# Altium schematic sheet SOC.SchDoc(SOC)
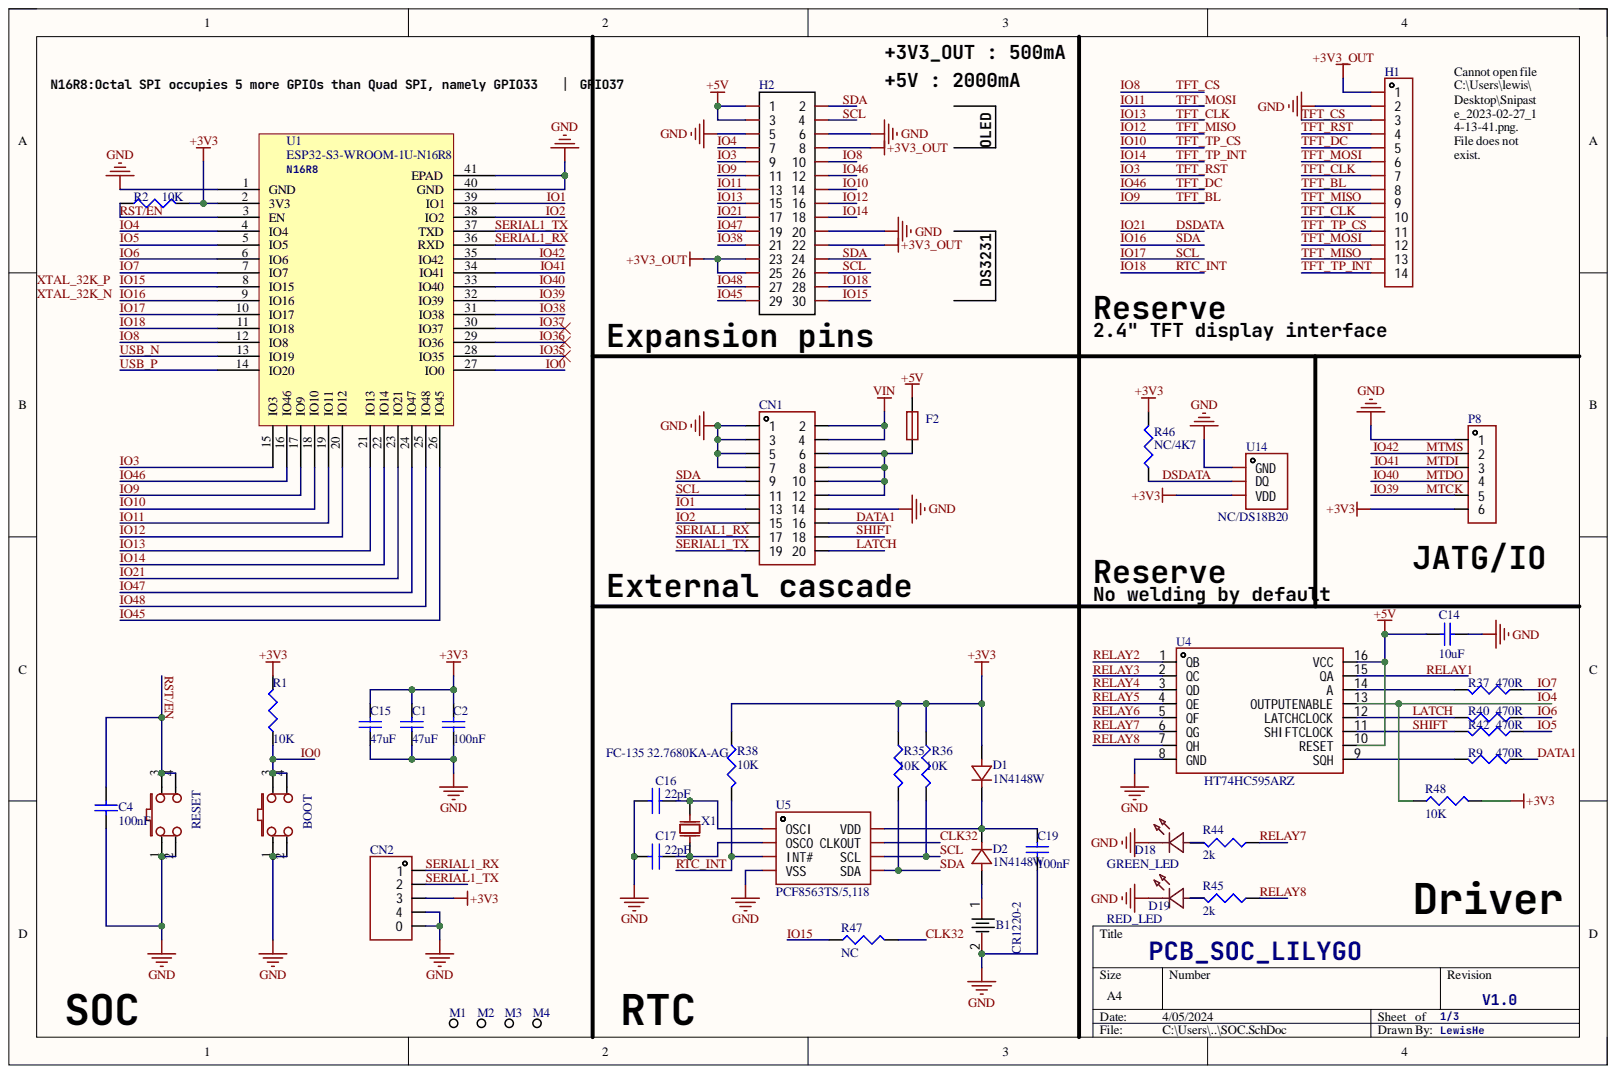
[diagram p0: SOC.SchDoc]

## Parts
B1  CR1220-2  [BAT-SMD_CR1220-2]
C1  47uF  [C0805]
C2  100nF  [C0603]
C4  100nF  [C0603]
C14  10uF  [C0603]
C15  47uF  [C0805]
C16  22pF  [C0402]
C17  22pF  [C0402]
C19  100nF  [C0603]
CN1  321020SG0ABK00A01  [IDC-TH_20P-P2.54-V-R2-C10-S2.54]  (value not drawn)
CN2  BM04B-SRSS-TB(LF)(SN)  [CONN-SMD_BM04B-SRSS-TB]  (value not drawn)
D1  1N4148W  [SOD-123_L2.7-W1.6-LS3.8-RD]
D2  1N4148W  [SOD-123_L2.7-W1.6-LS3.8-RD]
D18  GREEN_LED  [LED0603_RED]
D19  RED_LED  [LED0603_RED]
F2  BSMD1206-200-12V  [F1206]  (value not drawn)
H1  PM254-1-14-Z-8.5  [HDR-TH_14P-P2.54-V-F-1]  (value not drawn)
H2  B-2200S30P-B120  [HDR-TH_30P-P2.54-V-F-R2-C15-S2.54-1]  (value not drawn)
KEY1  RESET  [KEY-SMD_4P-L6.0-W6.0-P4.50-LS10.0]
KEY2  BOOT  [KEY-SMD_4P-L6.0-W6.0-P4.50-LS10.0]
M1  M3螺丝孔  [M3螺丝孔]  (value not drawn)
M2  M3螺丝孔  [M3螺丝孔]  (value not drawn)
M3  M3螺丝孔  [M3螺丝孔]  (value not drawn)
M4  M3螺丝孔  [M3螺丝孔]  (value not drawn)
P8  PZ254V-11-06P  [HDR-TH_6P-P2.54-V-M]  (value not drawn)
R1  10K  [R0402]
R2  10K  [R0603]
R9  470R  [R0402]
R35  10K  [R0603]
R36  10K  [R0603]
R37  470R  [R0402]
R38  10K  [R0603]
R40  470R  [R0402]
R42  470R  [R0402]
R44  2k  [R0402]
R45  2k  [R0402]
R46  NC/4K7  [R0603]
R47  NC  [R0402]
R48  10K  [R0402]
U1  ESP32-S3-WROOM-1U-N16R8  [ESP32-S3-WROOM-1]
U4  HT74HC595ARZ  [SOIC-16_L9.9-W3.9-P1.27-LS6.0-BL]
U5  PCF8563TS/5,118  [TSSOP-8_L3.0-W3.0-P0.65-LS4.9-BL]
U14  NC/DS18B20  [TO-92-3_L4.9-W3.7-P1.27-L]
X1  FC-135 32.7680KA-AG  [CRYSTAL-SMD_L3.2-W1.5-2]

## Nets
+3V3: C1.1 C2.1 C15.1 CN2.3 D1.2 P8.6 R1.2 R2.2 R35.1 R36.1 R38.1 R46.2 R48.2 U1.2(3V3) U14.3
+3V3_OUT: H1.1 H2.8 H2.22 H2.23 H2.25
+5V: C14.1 F2.2 H2.1 H2.3 U4.10(RESET) U4.16(VCC)
GND: B1.2 C1.2 C2.2 C4.2 C14.2 C15.2 C16.2 C17.2 C19.2 CN1.1 CN1.3 CN1.5 CN1.7 CN1.14 CN2.0 CN2.4 D18.2 D19.2 H1.2 H2.5 H2.6 H2.20 KEY1.1 KEY1.2 KEY2.1 KEY2.2 P8.1 U1.1(GND) U1.40(GND) U1.41(EPAD) U4.8(GND) U5.4(VSS) U14.1
VIN: CN1.2 CN1.4
CLK32: R47.2 U5.7(CLKOUT)
DATA1: CN1.16 R9.1
DSDATA: H2.17 R46.1 U1.23(IO21) U14.2
IO0: KEY2.3 KEY2.4 R1.1 U1.27(IO0)
IO1: CN1.13 U1.39(IO1)
IO2: CN1.15 U1.38(IO2)
IO3: H1.4 H2.9 U1.15(IO3)
IO4: H2.7 R48.1 U1.4(IO4) U4.13(OUTPUTENABLE)
IO5: R42.1 U1.5(IO5)
IO6: R40.1 U1.6(IO6)
IO7: R37.1 U1.7(IO7)
IO8: H1.3 H2.10 U1.12(IO8)
IO9: H1.8 H2.11 U1.17(IO9)
IO10: H1.11 H2.14 U1.18(IO10)
IO11: H1.6 H1.12 H2.13 U1.19(IO11)
IO12: H1.9 H1.13 H2.16 U1.20(IO12)
IO13: H1.7 H1.10 H2.15 U1.21(IO13)
IO14: H1.14 H2.18 U1.22(IO14)
IO15: H2.30 R47.1 U1.8(IO15)
IO16: CN1.9 H2.2 H2.24 R35.2 U1.9(IO16) U5.5(SDA)
IO17: CN1.11 H2.4 H2.26 R36.2 U1.10(IO17) U5.6(SCL)
IO18: H2.28 R38.2 U1.11(IO18) U5.3(INT#)
IO35: U1.28(IO35)
IO36: U1.29(IO36)
IO37: U1.30(IO37)
IO38: H2.21 U1.31(IO38)
IO39: P8.5 U1.32(IO39)
IO40: P8.4 U1.33(IO40)
IO41: P8.3 U1.34(IO41)
IO42: P8.2 U1.35(IO42)
IO45: H2.29 U1.26(IO45)
IO46: H1.5 H2.12 U1.16(IO46)
IO47: H2.19 U1.24(IO47)
IO48: H2.27 U1.25(IO48)
LATCH: CN1.20 R40.2 U4.12(LATCHCLOCK)
RELAY1: U4.15(QA)
RELAY2: U4.1(QB)
RELAY3: U4.2(QC)
RELAY4: U4.3(QD)
RELAY5: U4.4(QE)
RELAY6: U4.5(QF)
RELAY7: R44.1 U4.6(QG)
RELAY8: R45.1 U4.7(QH)
RST/EN: C4.1 KEY1.3 KEY1.4 R2.1 U1.3(EN)
SERIAL1_RX: CN1.17 CN2.1 U1.36(RXD)
SERIAL1_TX: CN1.19 CN2.2 U1.37(TXD)
SHIFT: CN1.18 R42.2 U4.11(SHIFTCLOCK)
USB_N: U1.13(IO19)
USB_P: U1.14(IO20)
NetB1_1: B1.1 D2.2
NetC16_1: C16.1 U5.1(OSCI) X1.2
NetC17_1: C17.1 U5.2(OSCO) X1.1
NetC19_1: C19.1 D1.1 D2.1 U5.8(VDD)
NetCN1_6: CN1.6 CN1.8 CN1.10 CN1.12 F2.1
NetD18_1: D18.1 R44.2
NetD19_1: D19.1 R45.2
NetR9_2: R9.2 U4.9(SQH)
NetR37_2: R37.2 U4.14(A)
XTAL_32K_N:
XTAL_32K_P:

## Library data of the parts
B1 = CR1220-2: 连接器类型:电池底座;适用电池规格:CR1220;工作温度范围:-;; Component Kind: Standard; Library Name: 常用.SCHLIB
C1 C2 C4 C14 C15 C19 = Cap: Capacitor; Component Kind: Standard; LatestRevisionDate: 17-Jul-2002; LatestRevisionNote: Re-released for DXP Platform.; Library Name: Miscellaneous Devices.IntLib; PackageDescription: Radial Cap, Thru-Hole; 2 Leads; 0.3 in Pin Spacing; PackageReference: RAD-0.3; Published: 8-Jun-2000; Signal Integrity: Cap; Simulation: CAP; Publisher: Altium Limited
  C1 C15: Value: 47uF
  C2 C4 C19: Value: 100nF
  C14: Value: 10uF
C16 C17 = CAP NP_9: Manufacture: WALSIN; Manufacturer: VISHAY; Manufacturer #1???: FengHua(风华); Manufacturer_ID: 1,004; Part Number: 0603F104Z250CT; AML P/N: 123-12Z4-1040C; Description #1: 介质材料[COG（NPO）/COH]通用型属I类电容，容量稳定，不随温度、时间和电压变化而变化，适用高频电路。; Description #2: X7R贴片电容属EIA规定的Class 2类材料电容，容量随温度、时间、电压变化而变化。; Descriptions: CAP CERAMIC .1uF -20%/+80% 25V 0603 SMD RoHS walsin; Dielectric: X7R; DNS: 0; Medium material: COG(NP0)/COH/X7R; PCB Footprint: C0805; Rating Voltage: 50(A)Vdc; Tolerance: ±10%; Voltage Rating: 25V; Component Kind: Standard; Datasheet: \\File1-shanghai\sha\Specifications\Datasheets_IC\_Manufacturer\Walsin\Capacitor\MLCC catalogue_200510.pdf; Manufacturer#2: Vishay（威世）; Manufacturer#3: AVX; Part Type: SMD Capacitance（贴片电容）; VoltageRating: 25V
CN1 = 321020SG0ABK00A01: 连接器类型:简牛座;间距:2.54mm;每排PIN数:10;排数:2;行距:2.54mm;封装形式:直插;触头材质:黄铜;触头镀层:金;; Component Kind: Standard; Library Name: 常用.SCHLIB
CN2 = BM04B-SRSS-TB(LF)(SN): 参考系列:SR/SZ;间距:1mm;插针结构:1x4P;排数:1;每排PIN数:4;行距:-;安装方式:-;触头材质:铜合金;; Component Kind: Standard; Library Name: 常用.SCHLIB
D1 D2 = 1N4148W: SOD-123 贴片二极管; Component Kind: Standard; Library Name: 常用.SCHLIB
D18 = LED0603: 绿灯; Component Kind: Standard; Library Name: 常用.SCHLIB
D19 = LED0603: 红灯; Component Kind: Standard; Library Name: 常用.SCHLIB
F2 = BSMD1206-200-12V: 最大电压:12V;最大电流:100A;保持电流:2A;跳闸电流:3.5A;消耗功率:800mW;初始态阻值(最小值):15mΩ;跳断后阻值(最大值):80mΩ;最大动作时间:1.5s;工作温度:-40℃~+85℃;; Component Kind: Standard; Library Name: 常用.SCHLIB
H2 = B-2200S30P-B120: 排数:双排;总孔位数:30;间距:2.54mm;插孔结构:2x15P;行距:2.54mm;安装类型:直插;塑高:-;触头材质:黄铜;触头镀层:金;; Component Kind: Standard; Library Name: 常用.SCHLIB
KEY1 KEY2 = BUTTON_1: 6x6x0.7 按键; Component Kind: Standard; Library Name: 常用.SCHLIB
P8 = PZ254V-11-06P: 排数:单排;总PIN位数:6;间距:2.54mm;插针结构:1x6P;行距:-;安装类型:直插;圆针/方针:方针;额定电流:-;额定电压:-;配合针长度:6mm;塑高:2.5mm;端接针长度:3mm;触头材质:黄铜;触头镀层:-;工作温度范围:-40℃~+105℃;颜色:黑色;; Component Kind: Standard; Library Name: 常用.SCHLIB
R1 R2 R9 R35-R38 R40 R42 R44-R48 = Res3: Resistor; Component Kind: Standard; LatestRevisionDate: 29-May-2009; LatestRevisionNote: IPC-7351 Footprint Added.; Library Name: Miscellaneous Devices.IntLib; PackageDescription: Chip Resistor; PackageReference: J1-0603; Published: 8-Jun-2000; Signal Integrity: Res; Simulation: RESISTOR; Publisher: Altium Limited
  R1 R2 R35 R36 R38 R48: Value: 10K
  R9 R37 R40 R42: Value: 470R
  R44 R45: Value: 2k
  R46: Value: NC/4K7
  R47: Value: NC
U1 = ESP32-S3-WROOM-1: N16R8; Component Kind: Standard; Library Name: MySchlib.SchLib
U4 = HT74HC595ARZ: 8位串行输入，串行或并行输出移位寄存器与输出锁存器; Component Kind: Standard; Library Name: 常用.SCHLIB
U5 = PCF8563TS/5,118: PCF8563/BM8563 MSOP8; Component Kind: Standard; Library Name: 常用.SCHLIB
U14 = DS18B20: Component Kind: Standard; Library Name: 常用.SCHLIB
X1 = FC-135 32.7680KA-AG: 327.68KHZ FC-135/3215; Component Kind: Standard; Library Name: 常用.SCHLIB
H1 = PM254-1-14-Z-8.5: Component Kind: Standard; Library Name: 常用.SCHLIB
M1-M4 = M3螺丝孔: Component Kind: Standard; Description: M3螺丝孔; Library Name: 常用.SCHLIB
